# Altium schematic sheet 08 SDMMC&RTC.SchDoc
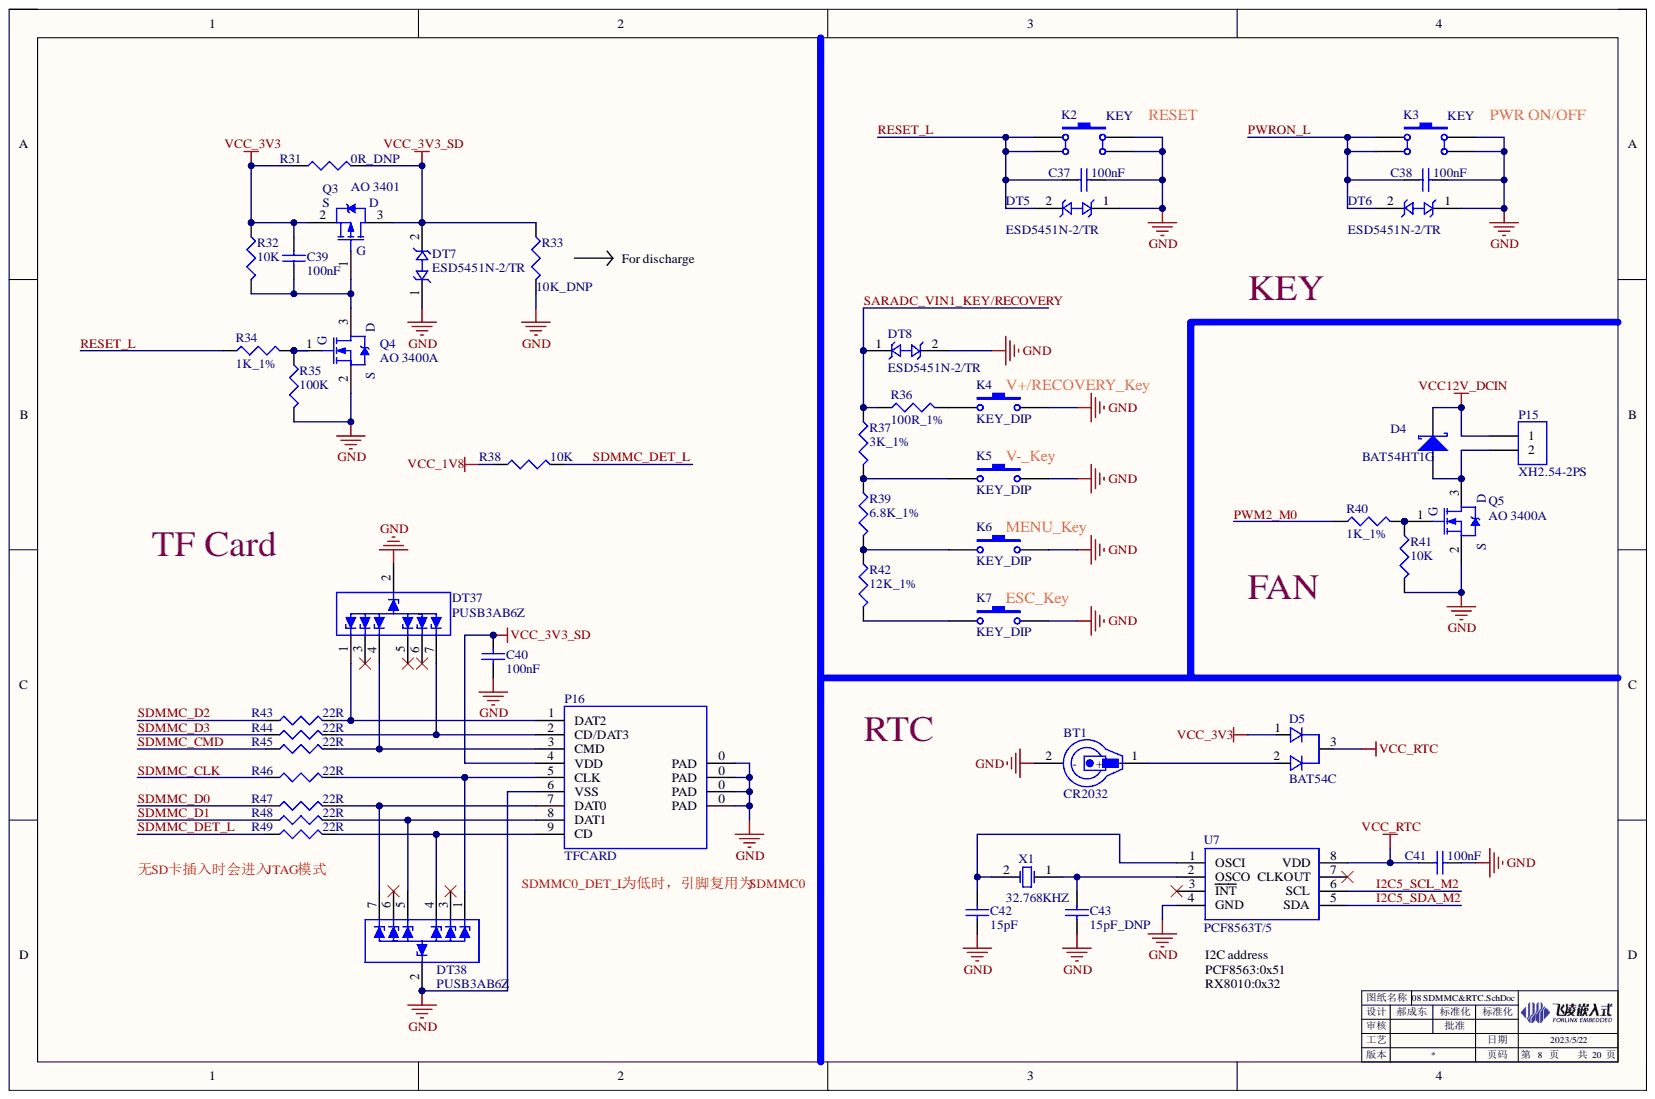
[diagram p0: 08 SDMMC&RTC.SchDoc]

## Parts
BT1  CR2032  [BAT_CR2032]
C37  100nF  [0402C]
C38  100nF  [0402C]
C39  100nF  [0402C]
C40  100nF  [0402C]
C41  100nF  [0402C]
C42  15pF  [0402C]
C43  15pF_DNP  [0402C]
D4  BAT54HT1G  [SOD_323]
D5  BAT54C  [SOT_233_N]
DT5  ESD5451N-2/TR  [0402TVS]
DT6  ESD5451N-2/TR  [0402TVS]
DT7  ESD5451N-2/TR  [0402TVS]
DT8  ESD5451N-2/TR  [0402TVS]
DT37  PUSB3AB6Z  [DFN2111-7]
DT38  PUSB3AB6Z  [DFN2111-7]
K2  KEY  [SW_6X6_SMT]
K3  KEY  [SW_6X6_SMT]
K4  KEY_DIP  [SW_6*6_DIP-4.35]
K5  KEY_DIP  [SW_6*6_DIP-4.35]
K6  KEY_DIP  [SW_6*6_DIP-4.35]
K7  KEY_DIP  [SW_6*6_DIP-4.35]
P15  XH2.54-2PS  [XH2.54_2P_V]
P16  TFCARD  [TF_CARD_SELF]
Q3  AO 3401  [SOT_233_W]
Q4  AO 3400A  [SOT_233_W]
Q5  AO 3400A  [SOT_233_W]
R31  0R_DNP  [0402R]
R32  10K  [0402R]
R33  10K_DNP  [0402R]
R34  1K_1%  [0402R]
R35  100K  [0402R]
R36  100R_1%  [0402R]
R37  3K_1%  [0402R]
R38  10K  [0402R]
R39  6.8K_1%  [0402R]
R40  1K_1%  [0402R]
R41  10K  [0402R]
R42  12K_1%  [0402R]
R43  22R  [0402R]
R44  22R  [0402R]
R45  22R  [0402R]
R46  22R  [0402R]
R47  22R  [0402R]
R48  22R  [0402R]
R49  22R  [0402R]
U7  PCF8563T/5  [SOP8_1.27]
X1  32.768KHZ  [CRYSTALS_3215_2PIN]

## Nets
GND: BT1.2 C37.1 C38.1 C40.1 C41.2 C42.1 C43.1 DT5.1 DT6.1 DT7.1 DT8.2 DT37.2 DT38.2 K2.2 K2.3 K3.2 K3.3 K4.2 K5.2 K6.2 K7.2 P16.0(PAD) P16.6(VSS) Q4.2 Q5.2 R33.2 R35.2 R41.2 U7.4(GND)
VCC12V_DCIN: D4.2 P15.1
VCC_1V8: R38.1
VCC_3V3: C39.2 D5.1 Q3.2 R31.1 R32.1
VCC_3V3_SD: C40.2 DT7.2 P16.4(VDD) Q3.3 R31.2 R33.1
VCC_RTC: C41.1 D5.3 U7.8(VDD)
I2C5_SCL_M2: U7.6(SCL)
I2C5_SDA_M2: U7.5(SDA)
PWM2_M0: R40.1
PWRON_L: C38.2 DT6.2 K3.1 K3.4
RESET_L: C37.2 DT5.2 K2.1 K2.4 R34.1
SARADC_VIN1_KEY/RECOVERY: DT8.1 R36.1 R37.1
SDMMC_CLK: R46.1
SDMMC_CMD: R45.1
SDMMC_D0: R47.1
SDMMC_D1: R48.1
SDMMC_D2: R43.1
SDMMC_D3: R44.1
SDMMC_DET_L: R38.2 R49.1
NetBT1_1: BT1.1 D5.2
NetC39_1: C39.1 Q3.1 Q4.3 R32.2
NetC42_2: C42.2 U7.1(OSCI) X1.2
NetC43_2: C43.2 U7.2(OSCO) X1.1
NetD4_1: D4.1 P15.2 Q5.3
NetDT37_1: DT37.1 P16.1(DAT2) R43.2
NetDT37_4: DT37.4 P16.3(CMD) R45.2
NetDT37_7: DT37.7 P16.2(CD/DAT3) R44.2
NetDT38_1: DT38.1 P16.5(CLK) R46.2
NetDT38_4: DT38.4 P16.9(CD) R49.2
NetDT38_5: DT38.5 P16.8(DAT1) R48.2
NetDT38_7: DT38.7 P16.7(DAT0) R47.2
NetK4_1: K4.1 R36.2
NetK5_1: K5.1 R37.2 R39.1
NetK6_1: K6.1 R39.2 R42.1
NetK7_1: K7.1 R42.2
NetQ4_1: Q4.1 R34.2 R35.1
NetQ5_1: Q5.1 R40.2 R41.1

Not connected: DT37 3 5 6; DT38 3 6; U7 3(INT) 7(CLKOUT)

## Library data of the parts
BT1 = 1120202003: Database Table Name: VALID$; 存货名称: 插装电池座; 序号: 1158; 规格型号: CR2032-BS-2-1; Component Kind: Standard; Library Name: Database_AD Library.DbLib; Value: CR2032; 存货编码: 1120202003
C37-C41 = 1110202039: Database Table Name: VALID$; 存货名称: 贴装叠层陶瓷电容; 序号: 626; 规格型号: 0402,100nF,25V,±10％,X5R; Component Kind: Standard; LatestRevisionDate: 17-Jul-2002; LatestRevisionNote: Re-released for DXP Platform.; Library Name: Database_AD Library.DbLib; PackageDescription: Radial Cap, Thru-Hole; 2 Leads; 0.3 in Pin Spacing; PackageReference: RAD-0.3; Published: 8-Jun-2000; Signal Integrity: Cap; Simulation: CAP; Value: 100nF; 存货编码: 1110202039; Publisher: Altium Limited
C42 C43 = 1110202005: Database Table Name: VALID$; 主计量单位名称: 个; 存货名称: 贴装叠层陶瓷电容; 安全库存: 36682; 序号: 575; 旧编码: 010201006; 旧规格型号: 0402 15pF 50V ±5％NPO; 规格型号: 0402,15pF,50V,±5％,NPO; Component Kind: Standard; LatestRevisionDate: 17-Jul-2002; LatestRevisionNote: Re-released for DXP Platform.; Library Name: Database_AD Library.DbLib; PackageDescription: Radial Cap, Thru-Hole; 2 Leads; 0.3 in Pin Spacing; PackageReference: RAD-0.3; Published: 8-Jun-2000; Signal Integrity: Cap; Simulation: CAP; 存货编码: 1110202005; Publisher: Altium Limited
  C42: Value: 15pF
  C43: Value: 15pF_DNP
D4 = 1110501028: Database Table Name: VALID$; 存货名称: 肖特基二极管; 序号: 2525; 规格型号: BAT54HT1G,SOD323; Code_JEDEC: DO-214-AA; Component Kind: Standard; LatestRevisionDate: 17-Jul-2002; LatestRevisionNote: Re-released for DXP Platform.; Library Name: Database_AD Library.DbLib; PackageDescription: DO-214-AA/SMB; 2 C-Bend Leads; Body 5.3 x 3.6 mm, inc. leads (LxW); PackageReference: SMB; Published: 8-Jun-2000; Signal Integrity: D Schottky; Simulation: SKYDIODE; Value: BAT54HT1G; 存货编码: 1110501028; Publisher: Altium Limited
D5 = 1110501005: Database Table Name: VALID$; 存货名称: 双肖特基二极管; 安全库存: 3634; 序号: 2485; 旧编码: 010601007; 旧规格型号: BAT54C，SOT-23-3; 规格型号: BAT54C,SOT-23-3; Component Kind: Standard; Library Name: Database_AD Library.DbLib; Value: BAT54C; 存货编码: 1110501005
DT5-DT8 = 1110503036: Database Table Name: VALID$; 存货名称: TVS管; 规格型号: ESD5451N-2/TR,DFN1006-2L; Component Kind: Standard; Library Name: Database_AD Library.DbLib; Value: ESD5451N-2/TR; 存货编码: 1110503036
DT37 DT38 = 1110503004: Database Table Name: VALID$; 主计量单位名称: 只; 存货名称: TVS管; 安全库存: 198; 序号: 2555; 旧编码: 010601080; 旧规格型号: PUSB3AB6Z xson7; 规格型号: PUSB3AB6Z,xson7; Component Kind: Standard; Library Name: Database_AD Library.DbLib; Value: PUSB3AB6Z; 存货编码: 1110503004
K2 K3 = 1120105006: Database Table Name: VALID$; 存货名称: 贴装按键开关; 序号: 3475; 规格型号: DTSM-62N-V-T/R,4P,6*6*5mm,棕色; Component Kind: Standard; LatestRevisionDate: 17-Jul-2002; LatestRevisionNote: Re-released for DXP Platform.; Library Name: Database_AD Library.DbLib; PackageDescription: Switch; 2 Leads; PackageReference: SPST-2; Published: 24-Mar-1999; Value: KEY; 存货编码: 1120105006; Publisher: Altium Limited
K4-K7 = 1120204014: Database Table Name: VALID$; 存货名称: 插装按键开关; 序号: 3500; 禁用状态: 否; 规格型号: 6*6*6mm,侧位; Component Kind: Standard; LatestRevisionDate: 17-Jul-2002; LatestRevisionNote: Re-released for DXP Platform.; Library Name: Database_AD Library.DbLib; PackageReference: SPST-2; Published: 24-Mar-1999; value: KEY_DIP; 存货编码: 1120204014; Publisher: Altium Limited
P15 = 1120201011: Database Table Name: VALID$; 存货名称: 插装连接器; 序号: 1265; 规格型号: XH,公头,2P,2.54mm,S; Component Kind: Standard; LatestRevisionDate: 17-Jul-2002; LatestRevisionNote: Re-released for DXP Platform.; Library Name: Database_AD Library.DbLib; Signal Integrity: Connector; Value: XH2.54-2PS; 存货编码: 1120201011; Publisher: Altium Limited
P16 = 1120104005: Database Table Name: VALID$; 存货名称: TF卡座; 安全库存: 803; 序号: 1164; 旧编码: 010401044; 旧规格型号: TF卡外焊自弹单弹 TFP09-2-12B; 规格型号: TF卡外焊自弹单弹,TFP09-2-12B; Component Kind: Standard; Library Name: Database_AD Library.DbLib; Value: TFCARD; 存货编码: 1120104005
Q3 = 1110505019: Database Table Name: VALID$; Note: Pin 2 is electrically connected to pin 4.; 存货名称: 场效应管; 序号: 2675; 规格型号: AO3401,SOT-23-3,P沟道; Component Kind: Standard; ComponentLink1Description: Manufacturer Link; ComponentLink1URL: http://www.irf.com/; DatasheetVersion: PD-9.814; LatestRevisionDate: 17-Jul-2002; LatestRevisionNote: Re-released for DXP Platform.; Library Name: Database_AD Library.DbLib; PackageDescription: TO, Thru-Hole, Vertical, Heatsink Mounted; 3 In-Line Leads; Pitch 2.54 mm; PackageReference: TO-220AB; PackageVersion: Feb-1997; Published: 8-Jun-2000; Simulation: IRF1010; VALUE: AO 3401; 存货编码: 1110505019; Publisher: Altium Limited
Q4 Q5 = 1110505027: Database Table Name: VALID$; Note: Pin 2 is electrically connected to pin 4.; 存货名称: 场效应管; 描述栏信息: 替换1110505011; 规格型号: AO3400A,SOT23,N沟道; Component Kind: Standard; DatasheetVersion: PD-9.814; LatestRevisionDate: 17-Jul-2002; LatestRevisionNote: Re-released for DXP Platform.; Library Name: Database_AD Library.DbLib; PackageDescription: TO, Thru-Hole, Vertical, Heatsink Mounted; 3 In-Line Leads; Pitch 2.54 mm; PackageReference: TO-220AB; PackageVersion: Feb-1997; Published: 8-Jun-2000; Simulation: IRF1010; VALUE: AO 3400A; 存货编码: 1110505027; Publisher: Altium Limited
R31 = 1110102001: Database Table Name: VALID$; 存货名称: 贴装电阻; 序号: 10; 规格型号: 0402,0Ω,±5％; Component Kind: Standard; Library Name: Database_AD Library.DbLib; Signal Integrity: Res; Simulation: RESISTOR; Value: 0R_DNP; 存货编码: 1110102001
R32 R33 R38 R41 = 1110102007: Database Table Name: VALID$; 存货名称: 贴装电阻; 序号: 17; 规格型号: 0402,10K,±5％; Component Kind: Standard; Library Name: Database_AD Library.DbLib; Signal Integrity: Res; Simulation: RESISTOR; 存货编码: 1110102007
  R32 R38 R41: Value: 10K
  R33: Value: 10K_DNP
R34 R40 = 1110102011: Database Table Name: VALID$; 存货名称: 贴装电阻; 序号: 21; 规格型号: 0402,1K,±1％; Component Kind: Standard; Library Name: Database_AD Library.DbLib; Signal Integrity: Res; Simulation: RESISTOR; Value: 1K_1%; 存货编码: 1110102011
R35 = 1110102004: Database Table Name: VALID$; 存货名称: 贴装电阻; 序号: 14; 规格型号: 0402,100K,±5％; Component Kind: Standard; Library Name: Database_AD Library.DbLib; Signal Integrity: Res; Simulation: RESISTOR; Value: 100K; 存货编码: 1110102004
R36 = 1110102073: Database Table Name: VALID$; 存货名称: 贴装电阻; 序号: 104; 规格型号: 0402,100Ω,±1％; Component Kind: Standard; Library Name: Database_AD Library.DbLib; Signal Integrity: Res; Simulation: RESISTOR; Value: 100R_1%; 存货编码: 1110102073
R37 = 1110102094: Database Table Name: VALID$; 存货名称: 贴装电阻; 序号: 128; 规格型号: 0402,3K,±1％; Component Kind: Standard; Library Name: Database_AD Library.DbLib; Signal Integrity: Res; Simulation: RESISTOR; Value: 3K_1%; 存货编码: 1110102094
R39 = 1110102016: Database Table Name: VALID$; 存货名称: 贴装电阻; 序号: 26; 规格型号: 0402,6.8K,±1％; Component Kind: Standard; Library Name: Database_AD Library.DbLib; Signal Integrity: Res; Simulation: RESISTOR; Value: 6.8K_1%; 存货编码: 1110102016
R42 = 1110102018: Database Table Name: VALID$; 存货名称: 贴装电阻; 序号: 28; 规格型号: 0402,12K,±1％; Component Kind: Standard; Library Name: Database_AD Library.DbLib; Signal Integrity: Res; Simulation: RESISTOR; Value: 12K_1%; 存货编码: 1110102018
R43-R49 = 1110102028: Database Table Name: VALID$; 存货名称: 贴装电阻; 序号: 41; 规格型号: 0402,22Ω,±5％; Component Kind: Standard; Library Name: Database_AD Library.DbLib; Signal Integrity: Res; Simulation: RESISTOR; Value: 22R; 存货编码: 1110102028
U7 = 1110405328: Database Table Name: VALID$; 存货名称: 常规IC; 规格型号: PCF8563T/5,SO-8; Component Kind: Standard; Library Name: Database_AD Library.DbLib; Value: PCF8563T/5; 存货编码: 1110405328
X1 = 1110601026: Database Table Name: VALID$; 主计量单位名称: 只; 存货名称: 贴装晶振; 安全库存: 16713; 序号: 3362; 旧编码: 010802017; 旧规格型号: 贴装 无源 32.768KHZ 32*15mm ±20ppm 12.5PF ABS7-32.768KHZ-T; 规格型号: 无源,32.768KHZ,32*15mm,±20ppm,12.5PF,ABS7-32.768KHZ-T（替代XDMCHCNDDF-32.768KHZ）; Component Kind: Standard; LatestRevisionDate: 17-Jul-2002; LatestRevisionNote: Re-released for DXP Platform.; Library Name: Database_AD Library.DbLib; PackageReference: R38; Published: 8-Jun-2000; Simulation: XTAL; Value: 32.768KHZ; 存货编码: 1110601026; Publisher: Altium Limited
